# Altium schematic sheet EJ1-PCB.SchDoc
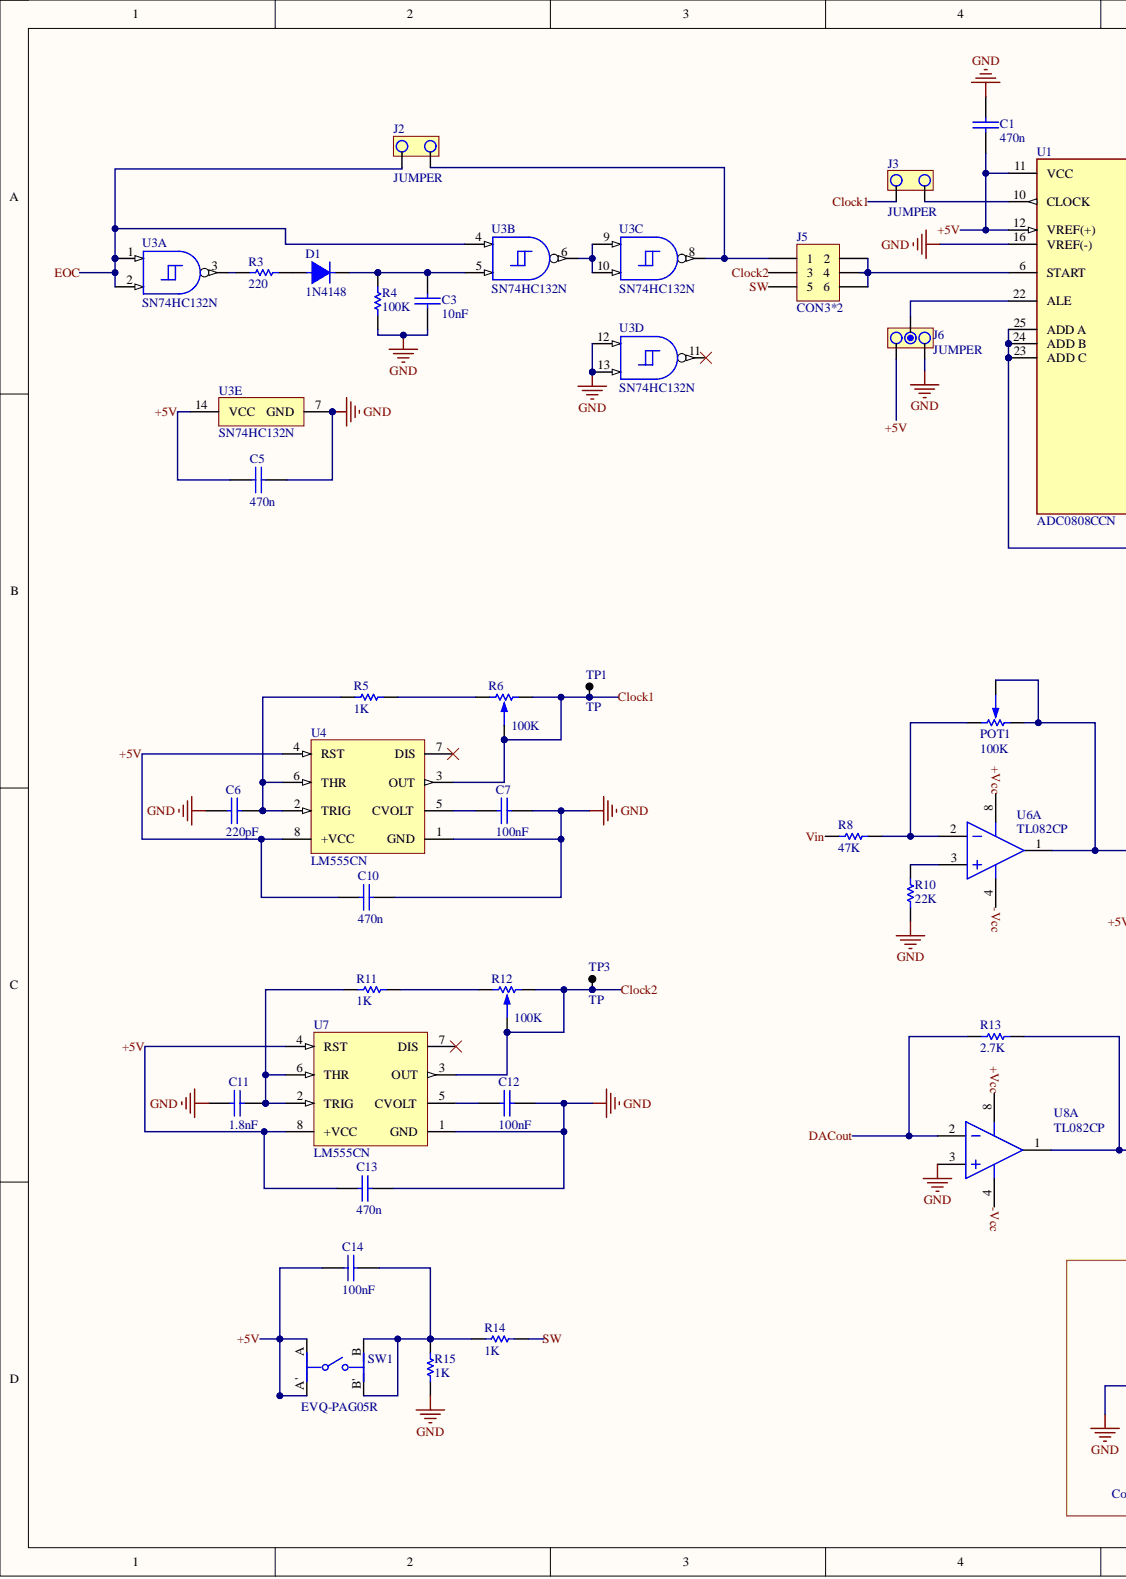
[diagram p0: EJ1-PCB.SchDoc, left]
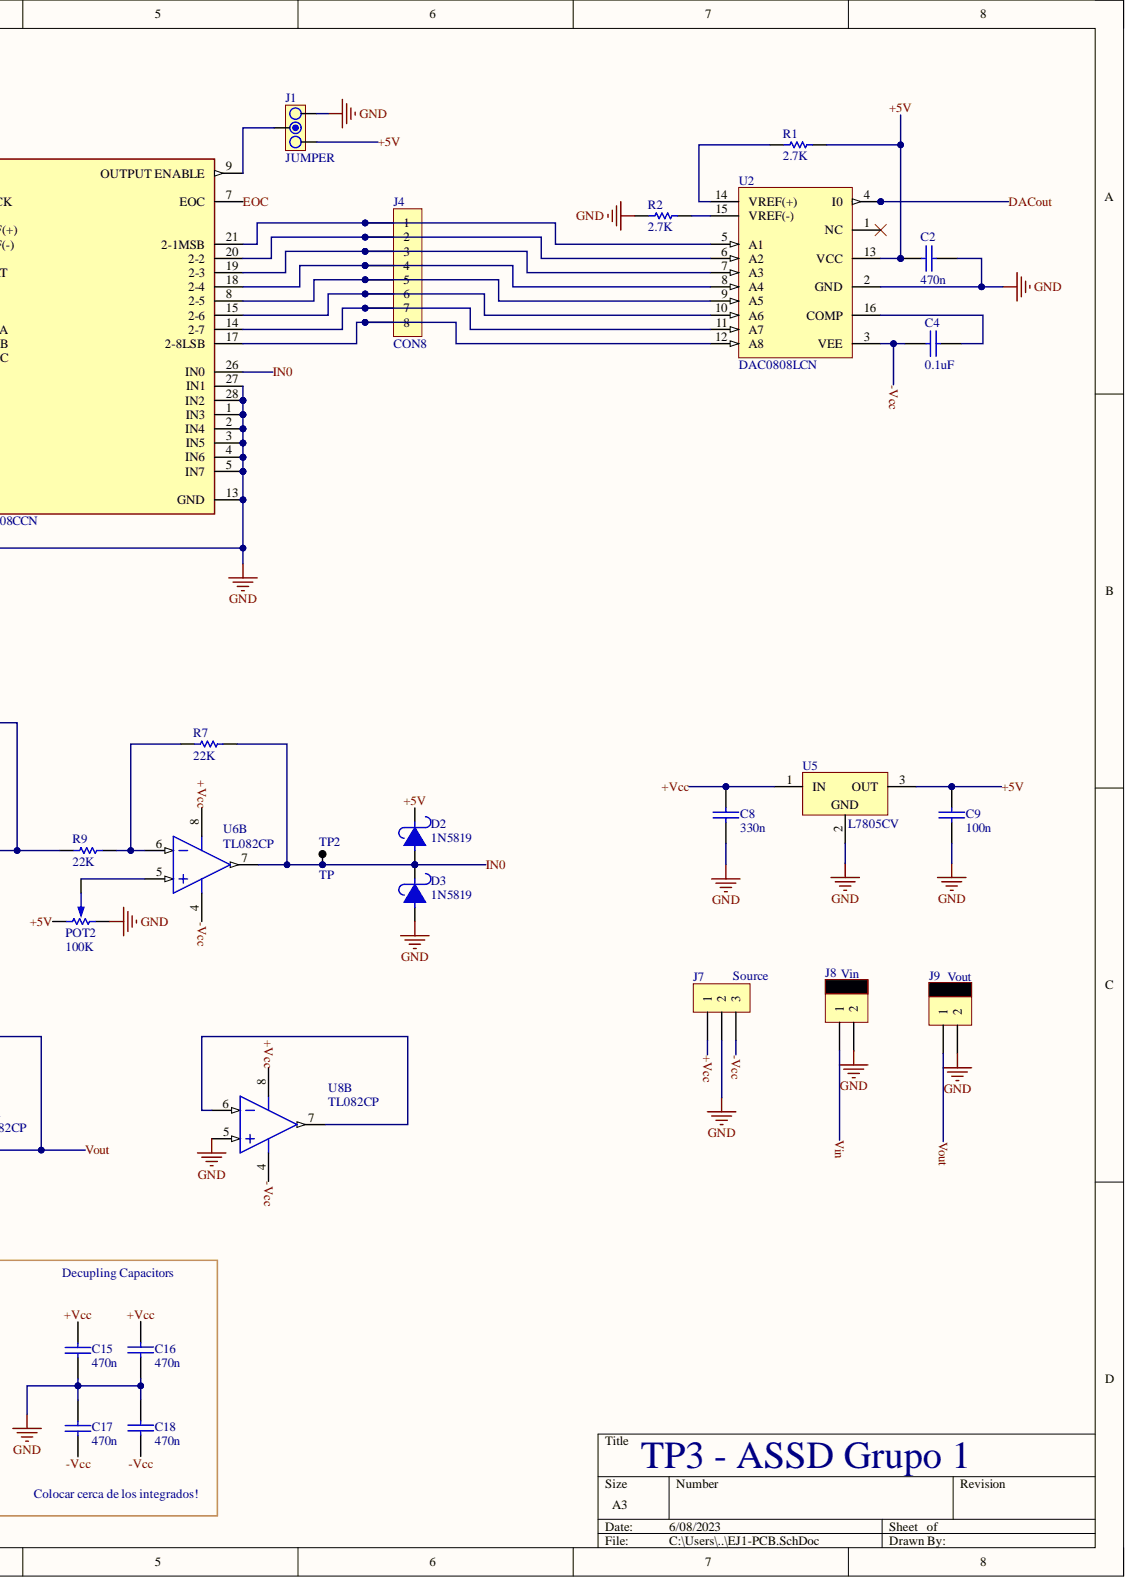
[diagram p1: EJ1-PCB.SchDoc, right]

## Parts
C1  470n  [0805]
C2  470n  [0805]
C3  10nF  [0805]
C4  0.1uF  [0805]
C5  470n  [0805]
C6  220pF  [0805]
C7  100nF  [0805]
C8  330n  [0805]
C9  100n  [0805]
C10  470n  [0805]
C11  1.8nF  [0805]
C12  100nF  [0805]
C13  470n  [0805]
C14  100nF  [0805]
C15  470n  [0805]
C16  470n  [0805]
C17  470n  [0805]
C18  470n  [0805]
D1  1N4148  [DO41]
D2  1N5819  [DO41]
D3  1N5819  [DO41]
J1  JUMPER  [JUMPER3]
J2  JUMPER  [JUMPER2]
J3  JUMPER  [JUMPER2]
J4  CON8  [SIP8]
J5  CON3*2  [SIP3*2]
J6  JUMPER  [JUMPER3]
J7  Source  [BORNERA3]
J8  Vin  [MOLEX2]
J9  Vout  [MOLEX2]
POT1  100K  [PRESET V]
POT2  100K  [PRESET V]
R1  2.7K  [0805]
R2  2.7K  [0805]
R3  220  [0805]
R4  100K  [0805]
R5  1K  [0805]
R6  100K  [PRESET V]
R7  22K  [0805]
R8  47K  [0805]
R9  22K  [0805]
R10  22K  [0805]
R11  1K  [0805]
R12  100K  [PRESET V]
R13  2.7K  [0805]
R14  1K  [0805]
R15  1K  [0805]
SW1  EVQ-PAG05R  [FP-EVQ-PAG05R-MFG]
TP1  TP  [TPTH]
TP2  TP  [TPTH]
TP3  TP  [TPTH]
U1  ADC0808CCN  [FP-N0028A-MFG]
U2  DAC0808LCN  [NFG0016A]
U3  SN74HC132N  [N0014A]  (parts A,B,C,D,E)
U4  LM555CN  [N08E]
U5  L7805CV  [TO220]
U6  TL082CP  [P0008A]  (parts A,B)
U7  LM555CN  [N08E]
U8  TL082CP  [P0008A]  (parts A,B)

## Nets
GND: C1.1 C2.2 C3.2 C5.2 C6.2 C7.2 C8.2 C9.2 C10.2 C11.2 C12.2 C13.2 C15.2 C16.2 C17.1 C18.1 D3.1 J1.1 J6.1 J7.2 J8.2 J9.2 POT2.3 R2.1 R4.1 R10.2 R15.1 U1.1(IN3) U1.2(IN4) U1.3(IN5) U1.4(IN6) U1.5(IN7) U1.13(GND) U1.16(VREF(-)) U1.23(ADD_C) U1.24(ADD_B) U1.25(ADD_A) U1.27(IN1) U1.28(IN2) U2.2(GND) U3.7(GND) U3.12 U3.13 U4.1(GND) U5.2 U7.1(GND) U8.3 U8.5
+5V: C1.2 C2.1 C5.1 C9.1 C10.1 C13.1 C14.2 D2.2 J1.3 J6.3 POT2.1 R1.2 SW1.A(A') SW1.A'(A) U1.11(VCC) U1.12(VREF(+)) U2.13(VCC) U3.14(VCC) U4.4(RST) U4.8(+VCC) U5.3 U7.4(RST) U7.8(+VCC)
+Vcc: C8.1 C15.1 C16.1 J7.1 U5.1 U6.8 U8.8
-Vcc: C4.1 C17.2 C18.2 J7.3 U2.3(VEE) U6.4 U8.4
Clock1: J3.2 R6.1 R6.2 TP1.1 U4.3(OUT)
Clock2: J5.3 R12.1 R12.2 TP3.1 U7.3(OUT)
DACout: R13.1 U2.4(I0) U8.2
EOC: J2.2 U1.7(EOC) U3.1 U3.2 U3.4
IN0: D2.1 D3.2 R7.2 TP2.1 U1.26(IN0) U6.7
SW: J5.5 R14.1
Vin: J8.1 R8.1
Vout: J9.1 R13.2 U8.1
NetC3_1: C3.1 D1.2 R4.2 U3.5
NetC4_2: C4.2 U2.16(COMP)
NetC6_1: C6.1 R5.1 U4.2(TRIG) U4.6(THR)
NetC7_1: C7.1 U4.5(CVOLT)
NetC11_1: C11.1 R11.1 U7.2(TRIG) U7.6(THR)
NetC12_1: C12.1 U7.5(CVOLT)
NetC14_1: C14.1 R14.2 R15.2 SW1.B(B') SW1.B'(B)
NetD1_1: D1.1 R3.2
NetJ1_2: J1.2 U1.9(OUTPUT_ENABLE)
NetJ2_1: J2.1 J5.1 U3.8
NetJ3_1: J3.1 U1.10(CLOCK)
NetJ4_1: J4.1 U1.21(2-1MSB) U2.5(A1)
NetJ4_2: J4.2 U1.20(2-2) U2.6(A2)
NetJ4_3: J4.3 U1.19(2-3) U2.7(A3)
NetJ4_4: J4.4 U1.18(2-4) U2.8(A4)
NetJ4_5: J4.5 U1.8(2-5) U2.9(A5)
NetJ4_6: J4.6 U1.15(2-6) U2.10(A6)
NetJ4_7: J4.7 U1.14(2-7) U2.11(A7)
NetJ4_8: J4.8 U1.17(2-8LSB) U2.12(A8)
NetJ5_2: J5.2 J5.4 J5.6 U1.6(START)
NetJ6_2: J6.2 U1.22(ALE)
NetPOT1_1: POT1.1 R8.2 U6.2
NetPOT1_2: POT1.2 POT1.3 R9.1 U6.1
NetPOT2_2: POT2.2 U6.5
NetR1_1: R1.1 U2.14(VREF(+))
NetR2_2: R2.2 U2.15(VREF(-))
NetR3_1: R3.1 U3.3
NetR5_2: R5.2 R6.3
NetR7_1: R7.1 R9.2 U6.6
NetR10_1: R10.1 U6.3
NetR11_2: R11.2 R12.3
NetU3_6: U3.6 U3.9 U3.10
NetU8_6: U8.6 U8.7

Not connected: U2 1(NC); U3 11; U4 7(DIS); U7 7(DIS)

## Library data of the parts
C1-C18 = CAP: Component Kind: Standard; Library Name: LIBEBAL.IntLib
D1 = DIODO: Component Kind: Standard; Library Name: LIBEBAL Tobi.IntLib
D2 D3 = DIODO SCHOTTKY: Component Kind: Standard; Library Name: LIBEBAL.IntLib
J1 J6 = JUMPER3: Component Kind: Standard; Library Name: LIBEBAL Tobi.IntLib
J2 = JUMPER: Just in case HC132 does not work; Component Kind: Standard; Library Name: LIBEBAL Tobi.IntLib
J7 = BORNERA 3: Component Kind: Standard; Library Name: LIBEBAL.IntLib
J8 J9 = MOLEX2: Component Kind: Standard; Library Name: LIBEBAL Tobi.IntLib
POT1 POT2 R6 R12 = PRESET: Component Kind: Standard; Library Name: LIBEBAL.IntLib
R1-R5 R7-R11 R13-R15 = RES: Component Kind: Standard; Library Name: LIBEBAL.IntLib
SW1 = CMP-05618-000039-1: SWITCH TACTILE SPST-NO 0.02A 15V; Actuator Color: Red; Actuator Length: 1.6mm; Actuator Type: Standard; Contact Current Rating: 20mA; Contact Resistance: 50mR; Electrical Life: 50000Cycles; Illumination Type: Non-Illuminated; Operating Force: 2.6N; Switch Function: Off-Mom; Switch Travel: 0.25mm; Throw Configuration: SPST; Component Kind: Standard; Contact Plating: Silver; Current Rating: 20mA; Depth: 6.5mm; Height: 5mm; Lead Free: Lead Free; Length: 6mm; Library Name: Altium Content Vault; Max Current Rating: 20mA; Max Operating Temperature: 70°C; Max Voltage Rating (DC): 15V; Mechanical Life: 50000Cycles; Min Operating Temperature: -20°C; Mount: Through Hole; Orientation: Straight; Package Quantity: 500; Packaging: Bulk; Radiation Hardening: No; REACH SVHC: No SVHC; RoHS Compliant: Yes; Series: EVQPA; Size: 6mm; Termination: PC Pin; Voltage Rating (DC): 15V; Width: 6mm
U1 = CMP-0054-00026-4: IC ADC 8BIT MPU 8CH MUX 28-DIP; Conversion Rate: 10KS/s; Converter Type: GENERAL PURPOSE; Input Type: SINGLE-ENDED; Interface: Parallel; Max Power Dissipation: 875mW; Max Supply Voltage: 6V; Min Supply Voltage: 4.5V; Nominal Supply Current: 300uA; Number of A/D Converters: 1; Number of Analog Inputs: 8; Operating Supply Voltage: 5V; Polarity: Unipolar; Power Consumption: 1.5mW; Resolution: 8b; Supply Type: Single; Case/Package: DIP; Component Kind: Standard; Height: 3.81mm; Lead Free: Contains Lead; Length: 36.83mm; Library Name: Altium Content Vault; Max Operating Temperature: 85°C; Min Operating Temperature: -40°C; Mount: Through Hole; Number of Bits: 8; Number of Channels: 8; Number of Converters: 1; Number of Elements: 1; Number of Inputs: 8; Number of Pins: 28; Package Quantity: 13; Packaging: Rail/Tube; Power Dissipation: 875mW; Radiation Hardening: No; REACH SVHC: No SVHC; Sampling Rate: 10KS/s; Width: 13.72mm
U2 = CMP-0061-00001-3: 8-Bit D/A Converter, 16-pin MDIP; Manufacturer: Texas Instruments; PackageTopMarking: NSUZXYYTTE#*DAC0808LCN*MC1408P8; PowerWise: N; Code_JEDEC: MS-001-BB; Component Kind: Standard; ComponentLink1Description: Datasheet URL; ComponentLink1URL: http://www.ti.com/general/docs/lit/getliterature.tsp?genericPartNumber=DAC0808&fileType=pdf; CompType: D/A Converter; Library Name: Altium Content Vault; PackageDescription: MDIP, .750 x .250 x .130in, 16 Lead, .100in Pitch; PackageReference: NFG0016A; PinCount: 16; RoHS: N
U3 = CMP-1627-00473-3: Quadruple Positive-NAND Gates With Schmitt-Trigger Inputs, N0014A, TUBE; Manufacturer: Texas Instruments; Bits(#): 4; F @ Nom Voltage(Max)(Mhz): 70; ICC @ Nom Voltage(Max)(mA): 0.02; Input Type: CMOS; Output Drive (IOL/IOH)(Max)(mA): 5.2/-5.2; Rating: Catalog; Schmitt Trigger: Yes; Technology Family: HC; tpd @ Nom Voltage(Max)(ns): 31; VCC(Max)(V): 6; VCC(Min)(V): 2; Voltage(Nom)(V): 5; Code_JEDEC: MS-001; Component Kind: Standard; DatasheetVersion: SCLS034F; Generic Part Number: SN74HC132; Library Name: Altium Content Vault; Operating Temperature Range(C): -40 to 85; Output Type: CMOS; PackageReference: N0014A
U4 U7 = CMP-0056-00017-2: Timer, 8-pin MDIP; Manufacturer: National Semiconductor; CompType: Analog IC; PackageTopMarking: NSUZXYTTE#*LM*555CN; PowerWise: N; Code_JEDEC: MS-001-BA; Component Kind: Standard; ComponentLink1Description: LM555 Datasheet; ComponentLink1URL: http://www.national.com/ds/LM/LM555.pdf; ComponentLink2Description: LM555 Web Page; ComponentLink2URL: http://www.national.com/pf/LM/LM555.html; ComponentLink3Description: N08E Package Page; ComponentLink3URL: http://www.national.com/packaging/folders/n08e.html; DatasheetVersion: Jul-2006; Library Name: Altium Content Vault; PackageDescription: MDIP, .365 x .250 x .130in, 8 Lead, .100in Pitch; PackageReference: N08E; PackageVersion: revG, May-2006; PinCount: 8; RevisionNote: Component URLs added; RoHS: N
U5 = CMP-0244-00483-1: Positive Voltage Regulator, 5V, 3-Pin TO-220; Component Kind: Standard; ComponentLink1Description: Manufacturer URL; ComponentLink1URL: http://www.st.com; ComponentLink2Description: Datasheet; ComponentLink2URL: http://www.st.com/internet/com/TECHNICAL_RESOURCES/TECHNICAL_LITERATURE/DATASHEET/CD00000444.pdf; ComponentLink3Description: Package Specification; ComponentLink3URL: http://www.st.com/internet/com/TECHNICAL_RESOURCES/TECHNICAL_LITERATURE/PACKAGE_INFORMATION/CD00004649.pdf; DatasheetVersion: 16/11/2011; Library Name: Altium Content Vault; PackageDescription: TO, Thru-Hole, Vertical, Heatsink Mounted; 3 In-Line Leads; Pitch 2.54 mm; PackageReference: TO220; PackageVersion: ver3.0
U6 U8 = CMP-0055-00158-5: JFET-Input Operational Amplifier, 7 to 36 V, 0 to 70 degC, 8-pin DIP (P8), Pb-Free (RoHS); Manufacturer: Texas Instruments; CMRR(Min)(dB): 70; GBW(Typ)(MHz): 3; IIB(Max)(pA): 400; Iq per channel(Max)(mA): 2.8; Number of Channels: 2; Offset Drift(Typ)(uV/C): 18; Rating: Catalog; Slew Rate(Typ)(V/us): 13; Sub Family: General Purpose Amplifier, High Supply Voltage Amplifier (>=30V); Total Supply Voltage(Max)(+5V is 5, +/-5V is 10): 36; Total Supply Voltage(Min)(+5V is 5, +/-5V is 10): 7; Vio (25C)(Max)(mV): 15; Code_JEDEC: MS-001; Component Kind: Standard; ComponentLink1Description: Manufacturer URL; ComponentLink1URL: http://www.ti.com/; ComponentLink2Description: Datasheet; ComponentLink2URL: http://www.ti.com/lit/ds/symlink/tl082.pdf; ComponentLink3Description: Package Specification; ComponentLink3URL: http://www.ti.com/litv/pdf/mpdi001b; DatasheetVersion: SLOS081G; Eco-Plan: Pb-Free (RoHS); Library Name: Altium Content Vault; Mounting Technology: Through Hole; PackageDescription: 8-Pin Plastic In-Line, Body 9.59 x 6.35 mm, Pitch 2.54 mm; PackageReference: P0008A; PackageVersion: 4040082/E, 04/2010; PartNumber: TL082CP; Vn at 1kHz(Typ)(nV/rtHz): 18
J3 = JUMPER: Component Kind: Standard; Library Name: LIBEBAL Tobi.IntLib
J4 = CON8: Component Kind: Standard; Library Name: LIBEBAL.IntLib
J5 = CON3*2: Component Kind: Standard; Library Name: LIBEBAL.IntLib
TP1-TP3 = TP: Component Kind: Standard; Library Name: LIBEBAL.IntLib
